# Altium schematic sheet controller_8266.SchDoc
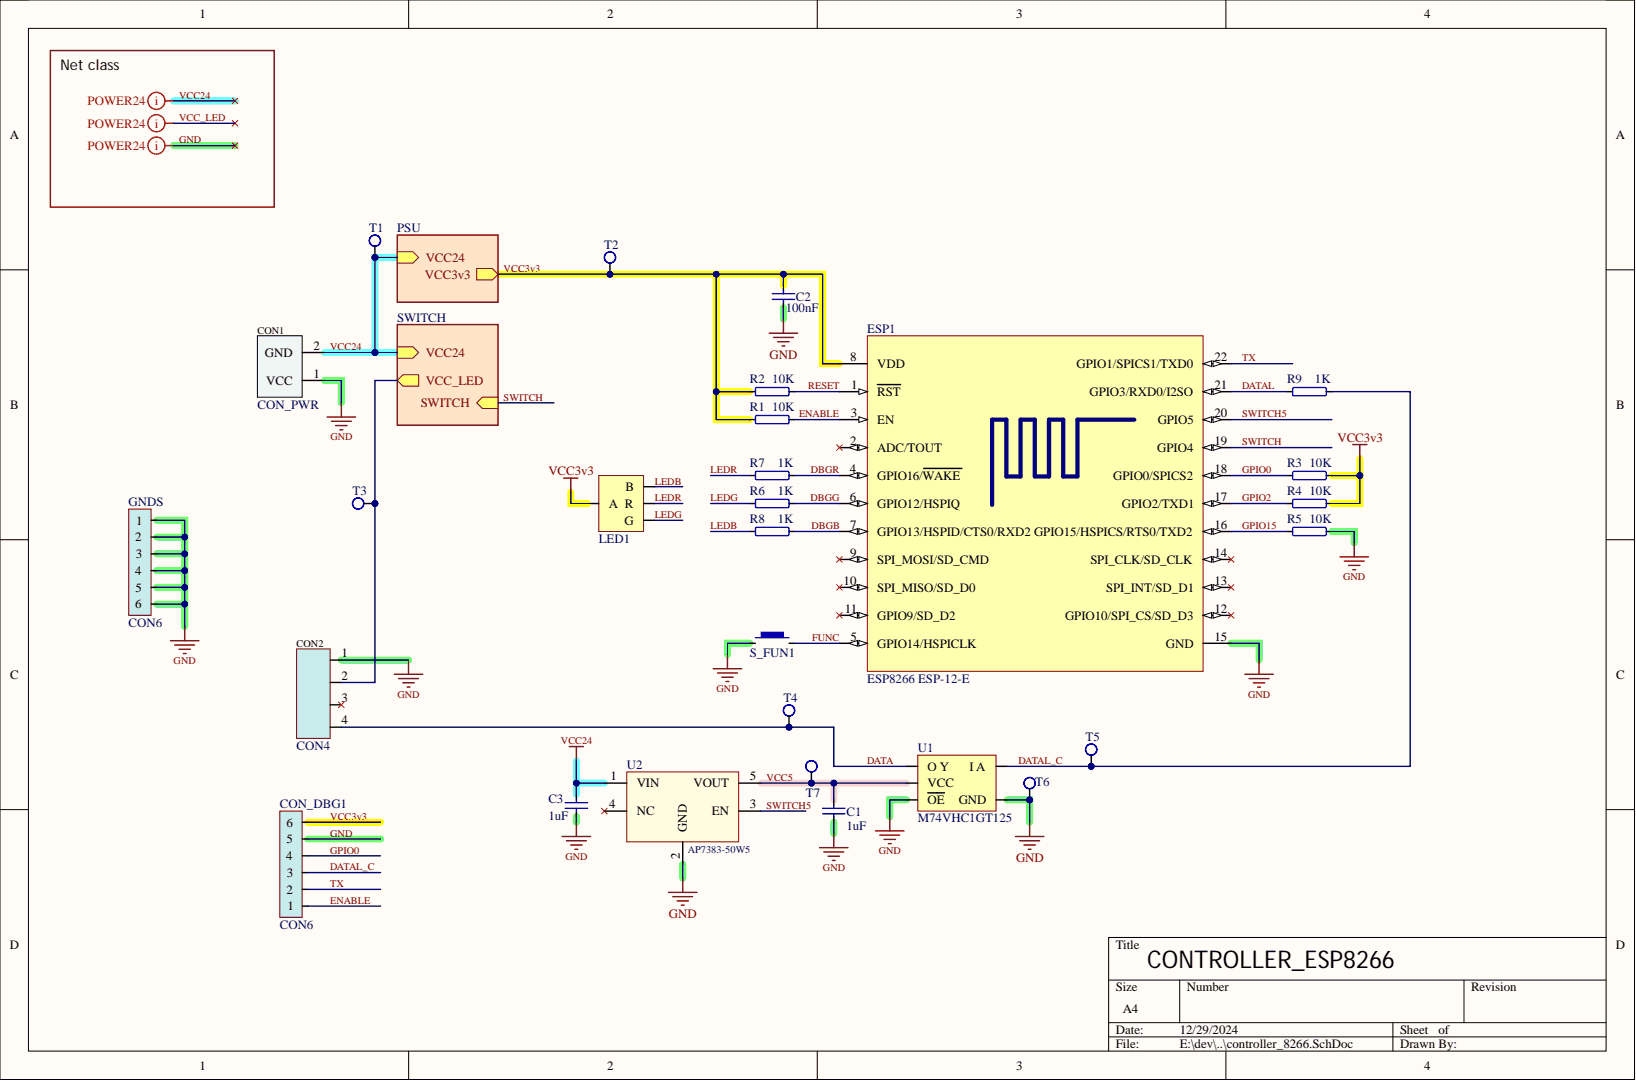
[diagram p0: controller_8266.SchDoc]

## Parts
C1  value 1uF  [CAPC_0603_1608X08L]  (hidden comment: Cap)
C2  value 100nF  [CAPC_0603_1608X08L]  (hidden comment: Cap)
C3  value 1uF  [CAPC_0603_1608X08L]  (hidden comment: Cap)
CON1  CON_PWR  [CONPWR]  (no BOM)
CON2  CON4  [CONNECTOR]  (no BOM)
CON_DBG1  CON6  [CON2X3]  (no BOM)
ESP1  ESP8266 ESP-12-E  [ESP8266 ESP-12-E]
GNDS  CON6  [CON2X3]  (no BOM)
LED1  RGB LED Common anode  [PLCC-4]  (value not drawn)
R1  value 10K  [RESC_0603_1608X08L]  (hidden comment: Res2)
R2  value 10K  [RESC_0603_1608X08L]  (hidden comment: Res2)
R3  value 10K  [RESC_0603_1608X08L]  (hidden comment: Res2)
R4  value 10K  [RESC_0603_1608X08L]  (hidden comment: Res2)
R5  value 10K  [RESC_0603_1608X08L]  (hidden comment: Res2)
R6  value 1K  [RESC_0603_1608X08L]  (hidden comment: Res2)
R7  value 1K  [RESC_0603_1608X08L]  (hidden comment: Res2)
R8  value 1K  [RESC_0603_1608X08L]  (hidden comment: Res2)
R9  value 1K  [RESC_0603_1608X08L]  (hidden comment: Res2)
S_FUN1  4-1437565-1  [EVQP7C01P]  (value not drawn)
T1  Testpoint  [TESTPOINT]  (value not drawn)  (no BOM)
T2  Testpoint  [TESTPOINT]  (value not drawn)  (no BOM)
T3  Testpoint  [TESTPOINT]  (value not drawn)  (no BOM)
T4  Testpoint  [TESTPOINT]  (value not drawn)  (no BOM)
T5  Testpoint  [TESTPOINT]  (value not drawn)  (no BOM)
T6  Testpoint  [TESTPOINT]  (value not drawn)  (no BOM)
T7  Testpoint  [TESTPOINT]  (value not drawn)  (no BOM)
U1  M74VHC1GT125  [SOT95P285X130-5N]
U2  AP7383-50W5  [SOT95P285X130-5N]

## Nets
DATA: CON2.4 T4.1 U1.4(O_Y)
DATAL: ESP1.21(GPIO3/RXD0/I2SO) R9.2
DATAL_C: CON_DBG1.3 R9.1 T5.1 U1.2(I_A)
DBGB: ESP1.7(GPIO13/HSPID/CTS0/RXD2) R8.2
DBGG: ESP1.6(GPIO12/HSPIQ) R6.2
DBGR: ESP1.4(GPIO16/WAKE) R7.2
ENABLE: CON_DBG1.1 ESP1.3(EN) R1.2
FUNC: ESP1.5(GPIO14/HSPICLK) S_FUN1.1
GND: C1.1 C2.2 C3.1 CON1.1 CON2.1 CON_DBG1.5 ESP1.15(GND) GNDS.1 GNDS.2 GNDS.3 GNDS.4 GNDS.5 GNDS.6 R5.2 S_FUN1.2 T6.1 U1.1(OE) U1.3(GND) U2.2(GND)
GPIO0: CON_DBG1.4 ESP1.18(GPIO0/SPICS2) R3.1
GPIO2: ESP1.17(GPIO2/TXD1) R4.1
GPIO15: ESP1.16(GPIO15/HSPICS/RTS0/TXD2) R5.1
LEDB: LED1.B R8.1
LEDG: LED1.G R6.1
LEDR: LED1.R R7.1
RESET: ESP1.1(RST) R2.1
SWITCH: ESP1.19(GPIO4)
SWITCH5: ESP1.20(GPIO5) U2.3(EN)
TX: CON_DBG1.2 ESP1.22(GPIO1/SPICS1/TXD0)
VCC3v3: C2.1 CON_DBG1.6 ESP1.8(VDD) LED1.A R1.1 R2.2 R3.2 R4.2 T2.1
VCC5: C1.2 T7.1 U1.5(VCC) U2.5(VOUT)
VCC24: C3.2 CON1.2 T1.1 U2.1(VIN)
NetCON2_2: CON2.2 T3.1
NetCON2_3: CON2.3
NetESP1_2: ESP1.2(ADC/TOUT)
NetESP1_9: ESP1.9(SPI_MOSI/SD_CMD)
NetESP1_10: ESP1.10(SPI_MISO/SD_D0)
NetESP1_11: ESP1.11(GPIO9/SD_D2)
NetESP1_12: ESP1.12(GPIO10/SPI_CS/SD_D3)
NetESP1_13: ESP1.13(SPI_INT/SD_D1)
NetESP1_14: ESP1.14(SPI_CLK/SD_CLK)
NetU2_4: U2.4(NC)
VCC_LED:

## Library data of the parts
C1-C3 = Cap: Capacitor; Component Kind: Standard; LatestRevisionDate: 17-Jul-2002; LatestRevisionNote: Re-released for DXP Platform.; Library Name: controller_8266.SchLib; PackageDescription: Radial Cap, Thru-Hole; 2 Leads; 0.3 in Pin Spacing; PackageReference: RAD-0.3; Published: 8-Jun-2000; Publisher: Altium Limited
CON1 = CON_PWR: Header 2 way; Library Name: controller_8266.SchLib
CON2 = CON4: Header 4 way; Library Name: controller_8266.SchLib
CON_DBG1 GNDS = CON6: Debug header 6 way; Library Name: controller_8266.SchLib
LED1 = RGB_LED: Dot is _not_ anode!; Component Kind: Standard; Library Name: controller_8266.SchLib
R1-R9 = Res2: Resistor; Component Kind: Standard; LatestRevisionDate: 17-Jul-2002; LatestRevisionNote: Re-released for DXP Platform.; Library Name: controller_8266.SchLib; PackageDescription: Axial Device, Thru-Hole; 2 Leads; 0.4 in Pin Spacing; PackageReference: AXIAL-0.4; Published: 8-Jun-2000; Publisher: Altium Limited
S_FUN1 = 4-1437565-1: Tactile switch; Manufacturer_Name: TE Connectivity; Allied_Number: R1117787; Component Kind: Standard; Datasheet Link: http://uk.rs-online.com/web/p/products/1616046; Library Name: controller_8266.SchLib; Manufacturer_Part_Number: 4-1437565-1; RS Part Number: 1616046
U1 = M74VHC1GT125: Level shifter non inverting; Component Kind: Standard; Library Name: controller_8266.SchLib
ESP1 = ESP8266 ESP-12-E: Component Kind: Standard; Description: ESP8266 ESP-12-E; Library Name: controller_8266.SchLib
T1-T7 = Testpoint: Library Name: controller_8266.SchLib
U2 = AP7383-50W5: Component Kind: Standard; Library Name: controller_8266.SchLib
